# Altium schematic sheet хехема.SchDoc
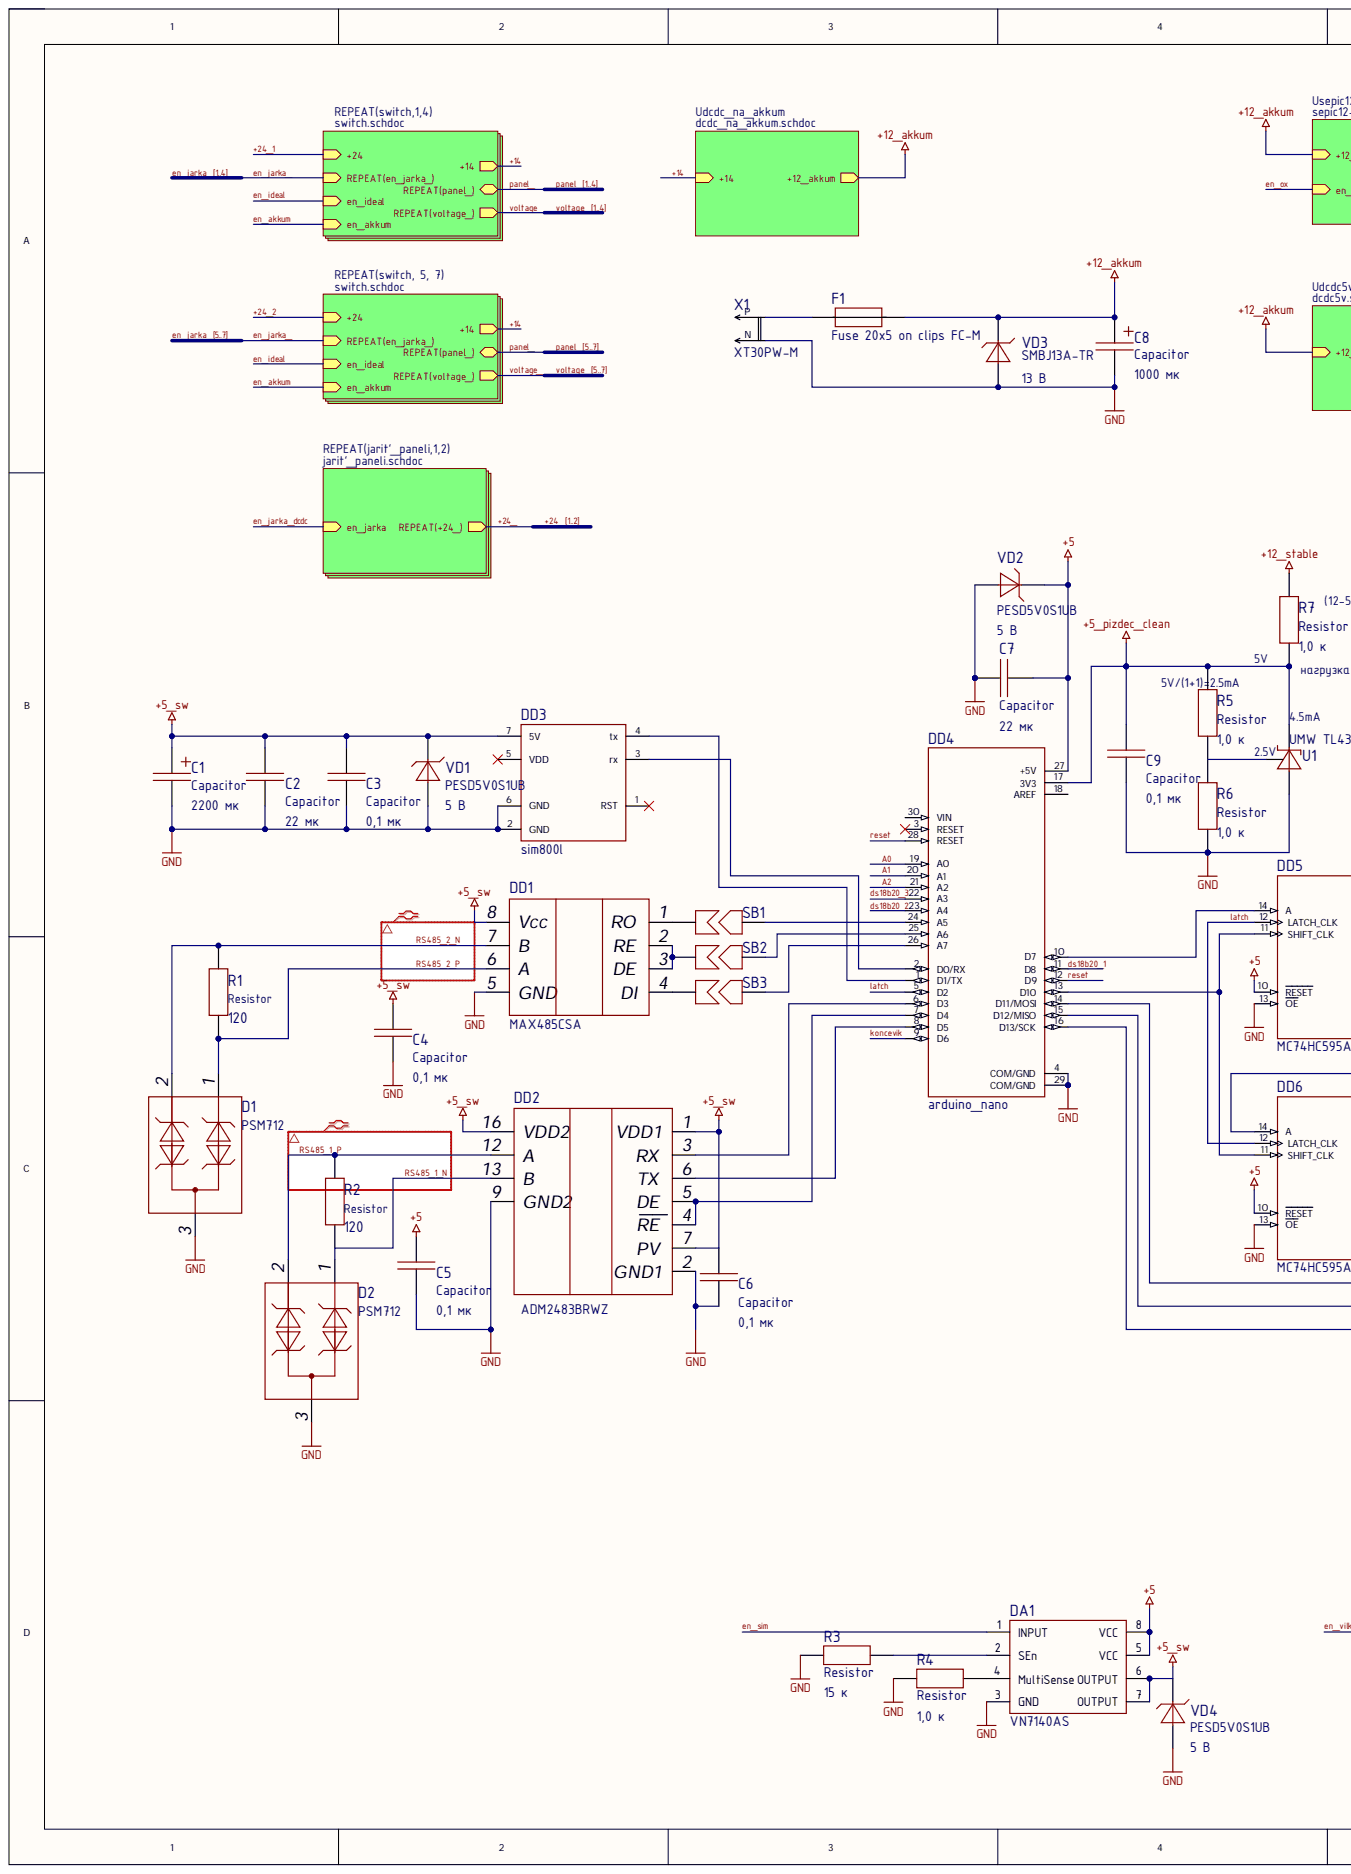
[diagram p0: хехема.SchDoc, left]
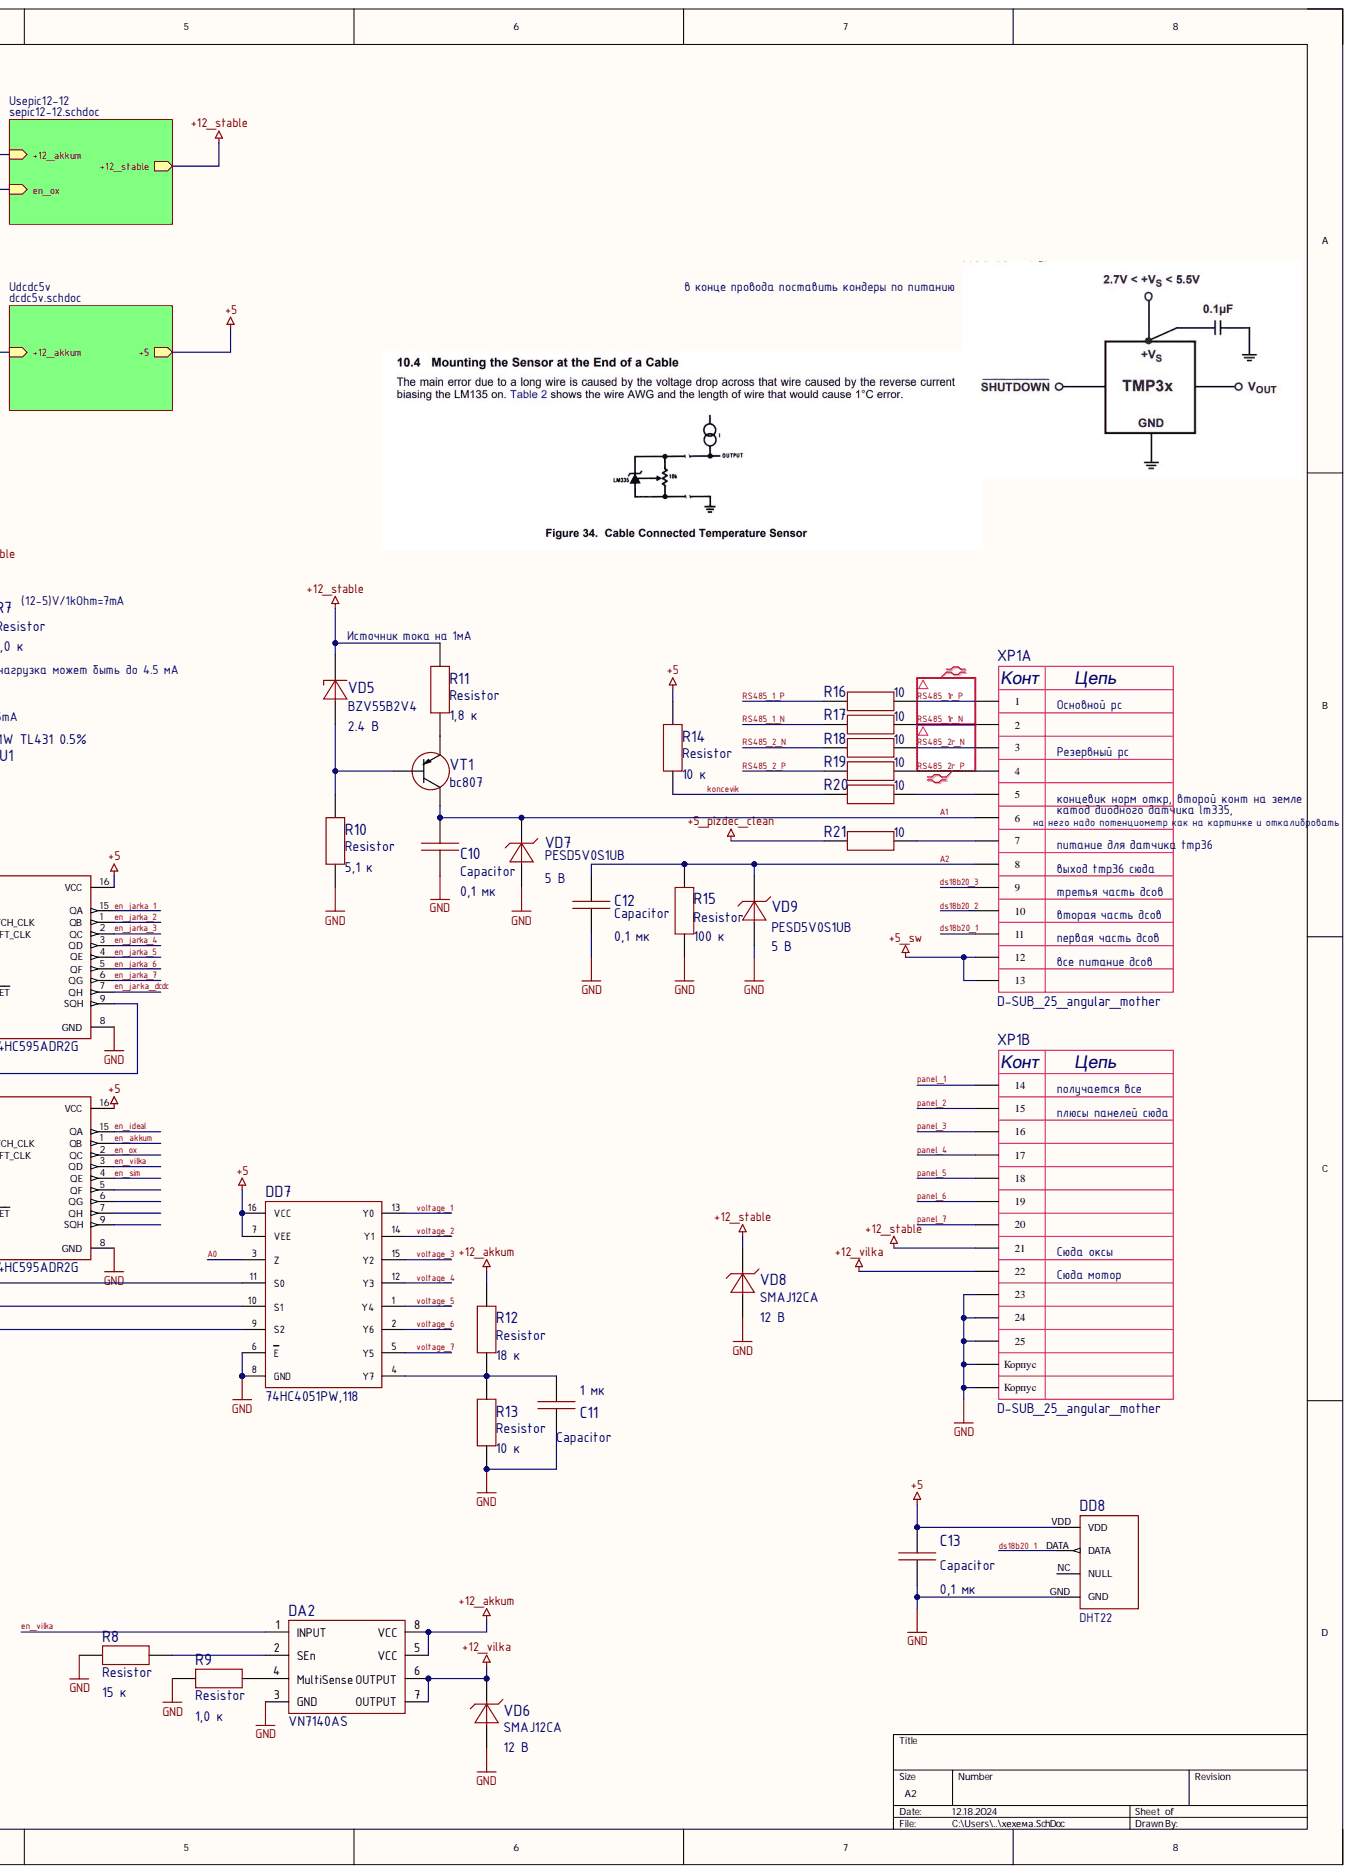
[diagram p1: хехема.SchDoc, right]

## Parts
C1  Capacitor  value 2200 мк  Capacity (uF): 2200  [10x20_radial]
C2  Capacitor  value 22 мк  [1210C]
C3  Capacitor  value 0,1 мк  Tolerance: 0,1  [0603C]
C4  Capacitor  value 0,1 мк  Tolerance: 0,1  [0603C]
C5  Capacitor  value 0,1 мк  Tolerance: 0,1  [0603C]
C6  Capacitor  value 0,1 мк  Tolerance: 0,1  [0603C]
C7  Capacitor  value 22 мк  [1210C]
C8  Capacitor  value 1000 мк  Capacity (uF): 1000  [SMD_cap_12,5x13,5]
C9  Capacitor  value 0,1 мк  Tolerance: 0,1  [0603C]
C10  Capacitor  value 0,1 мк  Tolerance: 0,1  [0603C]
C11  Capacitor  value 1 мк  [0603C]
C12  Capacitor  value 0,1 мк  Tolerance: 0,1  [0603C]
C13  Capacitor  value 0,1 мк  Tolerance: 0,1  [0603C]
D1  PSM712  [SOT23-3]
D2  PSM712  [SOT23-3]
DA1  VN7140AS  [SOP8]
DA2  VN7140AS  [SOP8]
DD1  MAX485CSA  [SOIC-8]
DD2  ADM2483BRWZ  [SOIC-16W]
DD3  sim800l  [sim800l]
DD4  arduino_nano  [arduino_nano]
DD5  MC74HC595ADR2G  [SOIC-16]
DD6  MC74HC595ADR2G  [SOIC-16]
DD7  74HC4051PW,118  [TSSOP-16]
DD8  DHT22  [DHT22]
F1  Fuse 20x5 on clips FC-M  [fuse_20x5_on_clips_FC-M]
R1  Resistor  value 120  [1206R]
R2  Resistor  value 120  [1206R]
R3  Resistor  value 15 к  [0603R]
R4  Resistor  value 1,0 к  [0603R]
R5  Resistor  value 1,0 к  [0603R]
R6  Resistor  value 1,0 к  [0603R]
R7  Resistor  value 1,0 к  [0603R]
R8  Resistor  value 15 к  [0603R]
R9  Resistor  value 1,0 к  [0603R]
R10  Resistor  value 5,1 к  [0603R]
R11  Resistor  value 1,8 к  [0603R]
R12  Resistor  value 18 к  [0603R]
R13  Resistor  value 10 к  [0603R]
R14  Resistor  value 10 к  [0603R]
R15  Resistor  value 100 к  [0603R]
R16  Resistor  value 10  [0603R]
R17  Resistor  value 10  [0603R]
R18  Resistor  value 10  [0603R]
R19  Resistor  value 10  [0603R]
R20  Resistor  value 10  [0603R]
R21  Resistor  value 10  [0603R]
SB1  SB  [0805SB]
SB2  SB  [0805SB]
SB3  SB  [0805SB]
U1  UMW TL431 0.5%  [SOT23-3]
VD1  PESD5V0S1UB  value 5 В  [SOD523]
VD2  PESD5V0S1UB  value 5 В  [SOD523]
VD3  SMBJ13A-TR  value 13 В  [SMB]
VD4  PESD5V0S1UB  value 5 В  [SOD523]
VD5  BZV55B2V4  value 2.4 В  Voltage nominal (V): 2.4  [mini_MELF_3.5mm]
VD6  SMAJ12CA  value 12 В  [SMA]
VD7  PESD5V0S1UB  value 5 В  [SOD523]
VD8  SMAJ12CA  value 12 В  [SMA]
VD9  PESD5V0S1UB  value 5 В  [SOD523]
VT1  bc807  [SOT23-3]
X1  XT30PW-M  [XT30PW-M]
XP1  D-SUB_25_angular_mother  [D-SUB_25_angular_mother]  (parts A,B)

## Nets
+5: C5.2 C7.1 C13.2 DA1.5(VCC) DA1.8(VCC) DD4.27(+5V) DD5.10(RESET) DD5.16(VCC) DD6.10(RESET) DD6.16(VCC) DD7.7(VEE) DD7.16(VCC) DD8.VDD R14.2 VD2.2
+5_pizdec_clean: C9.2 DD4.17(3V3) R5.2 R7.1 R21.1 U1.2
+5_sw: C1.1 C2.2 C3.2 C4.1 C6.2 DA1.6(OUTPUT) DA1.7(OUTPUT) DD1.8(Vcc) DD2.1(VDD1) DD2.7(PV) DD2.16(VDD2) DD3.7(5V) VD1.2 VD4.2 XP1.12 XP1.13
+12_akkum: C8.1 DA2.5(VCC) DA2.8(VCC) F1.2 R12.2 VD3.2
+12_stable: R7.2 R11.2 VD5.2 VD8.2 XP1.21
+12_vilka: DA2.6(OUTPUT) DA2.7(OUTPUT) VD6.2 XP1.22
GND: C1.2 C2.1 C3.1 C4.2 C5.1 C6.1 C7.2 C8.2 C9.1 C10.1 C11.1 C12.1 C13.1 D1.3 D2.3 DA1.3(GND) DA2.3(GND) DD1.5(GND) DD2.2(GND1) DD2.9(GND2) DD3.2(GND) DD3.6(GND) DD4.4(COM/GND) DD4.29(COM/GND) DD5.8(GND) DD5.13(OE) DD6.8(GND) DD6.13(OE) DD7.6(E) DD7.8(GND) DD8.GND R3.1 R4.1 R6.1 R8.1 R9.1 R10.1 R13.1 R15.1 U1.3 VD1.1 VD2.1 VD3.1 VD4.1 VD6.1 VD7.1 VD8.1 VD9.1 X1.N XP1.23 XP1.24 XP1.25 XP1.SH1(Корпус) XP1.SH2(Корпус)
A0: DD4.19(A0) DD7.3(Z)
A1: C10.2 DD4.20(A1) VD7.2 VT1.3 XP1.6
A2: C12.2 DD4.21(A2) R15.2 VD9.2 XP1.8
ds18b20_1: DD4.11(D8) DD8.DATA XP1.11
ds18b20_2: DD4.23(A4) XP1.10
ds18b20_3: DD4.22(A3) XP1.9
en_akkum: DD6.1(QB)
en_ideal: DD6.15(QA)
en_jarka_1: DD5.15(QA)  [also labelled en_jarka_]
en_jarka_2: DD5.1(QB)  [also labelled en_jarka_]
en_jarka_3: DD5.2(QC)  [also labelled en_jarka_]
en_jarka_4: DD5.3(QD)  [also labelled en_jarka_]
en_jarka_5: DD5.4(QE)  [also labelled en_jarka_]
en_jarka_6: DD5.5(QF)  [also labelled en_jarka_]
en_jarka_7: DD5.6(QG)  [also labelled en_jarka_]
en_jarka_dcdc: DD5.7(QH)
en_ox: DD6.2(QC)
en_sim: DA1.1(INPUT) DD6.4(QE)
en_vilka: DA2.1(INPUT) DD6.3(QD)
koncevik: DD4.9(D6) R14.1 R20.1
latch: DD4.5(D2) DD5.12(LATCH_CLK) DD6.12(LATCH_CLK)
panel_1: XP1.14  [also labelled panel_]
panel_2: XP1.15  [also labelled panel_]
panel_3: XP1.16  [also labelled panel_]
panel_4: XP1.17  [also labelled panel_]
panel_5: XP1.18  [also labelled panel_]
panel_6: XP1.19  [also labelled panel_]
panel_7: XP1.20  [also labelled panel_]
reset: DD4.12(D9) DD4.28(RESET)
RS485_1_N: D2.1 DD2.13(B) R2.1 R17.1
RS485_1_P: D2.2 DD2.12(A) R2.2 R16.1
RS485_1r_N: R17.2 XP1.2
RS485_1r_P: R16.2 XP1.1
RS485_2_N: D1.2 DD1.7(B) R1.2 R18.1
RS485_2_P: D1.1 DD1.6(A) R1.1 R19.1
RS485_2r_N: R18.2 XP1.3
RS485_2r_P: R19.2 XP1.4
voltage_1: DD7.13(Y0)  [also labelled voltage_]
voltage_2: DD7.14(Y1)  [also labelled voltage_]
voltage_3: DD7.15(Y2)  [also labelled voltage_]
voltage_4: DD7.12(Y3)  [also labelled voltage_]
voltage_5: DD7.1(Y4)  [also labelled voltage_]
voltage_6: DD7.2(Y6)  [also labelled voltage_]
voltage_7: DD7.5(Y5)  [also labelled voltage_]
NetC11_2: C11.2 DD7.4(Y7) R12.1 R13.2
NetDA1_2: DA1.2(SEn) R3.2
NetDA1_4: DA1.4(MultiSense) R4.2
NetDA2_2: DA2.2(SEn) R8.2
NetDA2_4: DA2.4(MultiSense) R9.2
NetDD1_1: DD1.1(RO) SB1.1
NetDD1_2: DD1.2(RE) DD1.3(DE) SB2.1
NetDD1_4: DD1.4(DI) SB3.1
NetDD2_3: DD2.3(RX) DD4.6(D3)
NetDD2_4: DD2.4(RE) DD2.5(DE) DD4.7(D4)
NetDD2_6: DD2.6(TX) DD4.8(D5)
NetDD3_1: DD3.1(RST)
NetDD3_3: DD3.3(rx) DD4.2(D0/RX)
NetDD3_4: DD3.4(tx) DD4.1(D1/TX)
NetDD3_5: DD3.5(VDD)
NetDD4_3: DD4.3(RESET)
NetDD4_10: DD4.10(D7) DD5.14(A)
NetDD4_13: DD4.13(D10) DD5.11(SHIFT_CLK) DD6.11(SHIFT_CLK)
NetDD4_14: DD4.14(D11/MOSI) DD7.11(S0)
NetDD4_15: DD4.15(D12/MISO) DD7.10(S1)
NetDD4_16: DD4.16(D13/SCK) DD7.9(S2)
NetDD4_18: DD4.18(AREF)
NetDD4_24: DD4.24(A5) SB1.2
NetDD4_25: DD4.25(A6) SB2.2
NetDD4_26: DD4.26(A7) SB3.2
NetDD4_30: DD4.30(VIN)
NetDD5_9: DD5.9(SQH) DD6.14(A)
NetDD6_5: DD6.5(QF)
NetDD6_6: DD6.6(QG)
NetDD6_7: DD6.7(QH)
NetDD6_9: DD6.9(SQH)
NetDD8_NC: DD8.NC(NULL)
NetF1_1: F1.1 X1.P
NetR5_1: R5.1 R6.2 U1.1
NetR10_2: R10.2 VD5.1 VT1.1
NetR11_1: R11.1 VT1.2
NetR20_2: R20.2 XP1.5
NetR21_2: R21.2 XP1.7
+14:
+24_1:  [also labelled +24_]
+24_2:  [also labelled +24_]
en_jarka_[1..4]:  [also labelled en_jarka_]
en_jarka_[5..7]:  [also labelled en_jarka_]
panel_[1..4]:  [also labelled panel_]
panel_[5..7]:  [also labelled panel_]
voltage_[1..4]:  [also labelled voltage_]
voltage_[5..7]:  [also labelled voltage_]

## Library data of the parts
C1 = Capacitor_2200u_10V_10x20: Конденсатор радиальный 2200мкФ 10В ±20% 10*19; Database Table Name: Capacitors_electrolytic$; Tolerance: 20; Type: Aluminum; Value: 2200 мк; Voltage (V): 10; Component Kind: Standard; Library Name: Hydrolib.DbLib; Part Number: Capacitor_2200u_10V_10x20
C2 = Capacitor_22u_10_25V_X7R_1210C: Конденсатор; Capacity (nF): 22000; Database Table Name: Capacitors_ceramic$; Dielectric: X7R; Tolerance: 0,1; Voltage (V): 25; Component Kind: Standard; Library Name: Hydrolib.DbLib; Part Number: Capacitor_22u_10_25V_X7R_1210C
C3 C4 C6 C12 C13 = Capacitor_0,1u_10_50V_X7R_0603C: Конденсатор; Capacity (nF): 100; Database Table Name: Capacitors_ceramic$; Dielectric: X7R; Voltage (V): 50; Component Kind: Standard; Library Name: Hydrolib.DbLib; Part Number: Capacitor_0,1u_10_50V_X7R_0603C
C5 C9 C10 = Capacitor_0,1u_10_50V_X7R_0603C: Конденсатор; Capacity (nF): 100; Database Table Name: Capacitors_ceramic$; Dielectric: X7R; Value: 0,1 мк; Voltage (V): 50; Component Kind: Standard; Library Name: Hydrolib.DbLib; Part Number: Capacitor_0,1u_10_50V_X7R_0603C
C7 = Capacitor_22u_10_25V_X7R_1210C: Конденсатор; Capacity (nF): 22000; Database Table Name: Capacitors_ceramic$; Dielectric: X7R; Tolerance: 0,1; Value: 22 мк; Voltage (V): 25; Component Kind: Standard; Library Name: Hydrolib.DbLib; Part Number: Capacitor_22u_10_25V_X7R_1210C
C8 = EEVFK1E102Q: Конденсатор алюминиевый электролитический 1000мкФ 25В 20% Low ESR 1100мА SMD12.5x13.5мм; Database Table Name: Capacitors_electrolytic$; Tolerance: 20; Type: Aluminum; Voltage (V): 25; Component Kind: Standard; Library Name: Hydrolib.DbLib; Part Number: EEVFK1E102Q
C11 = Capacitor_1u_10_50V_X7R_0603C: Конденсатор; Capacity (nF): 1000; Database Table Name: Capacitors_ceramic$; Dielectric: X7R; Tolerance: 0,1; Voltage (V): 50; Component Kind: Standard; Library Name: Hydrolib.DbLib; Part Number: Capacitor_1u_10_50V_X7R_0603C
D1 D2 = PSM712: Защитный диод для линии RS-485; Database Table Name: TVS$; Component Kind: Standard; Library Name: Hydrolib.DbLib; Part Number: PSM712
DA1 DA2 = VN7140AS: Силовой ключ верхнего плеча с аналоговой обратной связью MultiSense автомобильного применения; Database Table Name: Chips$; Component Kind: Standard; Library Name: Hydrolib.DbLib; Part Number: VN7140AS
DD1 = MAX485CSA: RS-485 приёмопередатчик; Database Table Name: Chips$; Component Kind: Standard; Library Name: Hydrolib.DbLib; Part Number: MAX485CSA
DD2 = ADM2483BRWZ: Прием передатчик RS485 с гальванической развязкой; Database Table Name: Chips$; Component Kind: Standard; Library Name: Hydrolib.DbLib; Part Number: ADM2483BRWZ
DD3 = sim800l: Четырехдиапазонный GSM/GPRS модуль, частоты: GSM 850 МГц, EGSM 900 МГц, DCS 1800 МГц и PCS 1900 МГц.; Database Table Name: Chips$; Component Kind: Standard; Library Name: Hydrolib.DbLib; Part Number: sim800l
DD4 = arduino_nano: ардуинка на стойках; Database Table Name: Chips$; MP: Arduino Nano; Availability: Not in stock; Check_prices: https://www.snapeda.com/parts/Arduino%20Nano/Arduino/view-part/?ref=eda; Component Kind: Standard; Library Name: Hydrolib.DbLib; MF: Arduino; Package: Non-Standard Arduino; Part Number: arduino_nano; Price: None; SnapEDA_Link: https://www.snapeda.com/parts/Arduino%20Nano/Arduino/view-part/?ref=snap
DD5 DD6 = MC74HC595ADR2G: 8-ми битный сдвиговый регистр; Database Table Name: Chips$; Component Kind: Standard; Library Name: Hydrolib.DbLib; Part Number: MC74HC595ADR2G
DD7 = 74HC4051PW,118: Мультиплексор аналоговый 8 в 1 КМОП кристалл, TSSOP16; Database Table Name: Chips$; Component Kind: Standard; Library Name: Hydrolib.DbLib; Part Number: 74HC4051PW,118
DD8 = DHT22: Датчик температуры и влажности на базе AM2302; Database Table Name: Other$; Component Kind: Standard; Library Name: Hydrolib.DbLib; Part Number: DHT22
F1 = Fuse 20x5 on clips FC-M: Предохранитель 20*5 на креплениях; Database Table Name: Other$; Value: {Номинал}; Component Kind: Standard; Library Name: Hydrolib.DbLib; Part Number: Fuse 20x5 on clips FC-M
R1 R2 = Resistor_120_1_0603: Резистор номиналом 120 Ом и точностью 1%; Database Table Name: Resistors$; Component Kind: Standard; Library Name: Hydrolib.DbLib; Part Number: Resistor_120_1_0603; Tolerance: 1%
R3 R8 = Resistor_15k_1_0603: Резистор номиналом 15 кОм и точностью 1%; Database Table Name: Resistors$; Component Kind: Standard; Library Name: Hydrolib.DbLib; Part Number: Resistor_15k_1_0603; Tolerance: 1%
R4 R6 R7 R9 = Resistor_1.0k_1_0603: Резистор номиналом 1,0 кОм и точностью 1%; Database Table Name: Resistors$; Component Kind: Standard; Library Name: Hydrolib.DbLib; Part Number: Resistor_1.0k_1_0603; Tolerance: 1%
R5 = Resistor_1.0k_1_0603: Резистор номиналом 1,0 кОм и точностью 1%; Database Table Name: Resistors$; Value: 1,0 к; Component Kind: Standard; Library Name: Hydrolib.DbLib; Part Number: Resistor_1.0k_1_0603; Tolerance: 1%
R10 = Resistor_5.1k_1_0603: Резистор номиналом 5,1 кОм и точностью 1%; Database Table Name: Resistors$; Component Kind: Standard; Library Name: Hydrolib.DbLib; Part Number: Resistor_5.1k_1_0603; Tolerance: 1%
R11 = Resistor_1.8k_1_0603: Резистор номиналом 1,8 кОм и точностью 1%; Database Table Name: Resistors$; Component Kind: Standard; Library Name: Hydrolib.DbLib; Part Number: Resistor_1.8k_1_0603; Tolerance: 1%
R12 = Resistor_18k_1_0603: Резистор номиналом 18 кОм и точностью 1%; Database Table Name: Resistors$; Component Kind: Standard; Library Name: Hydrolib.DbLib; Part Number: Resistor_18k_1_0603; Tolerance: 1%
R13 R14 = Resistor_10k_1_0603: Резистор номиналом 10 кОм и точностью 1%; Database Table Name: Resistors$; Component Kind: Standard; Library Name: Hydrolib.DbLib; Part Number: Resistor_10k_1_0603; Tolerance: 1%
R15 = Resistor_100k_1_0603: Резистор номиналом 100 кОм и точностью 1%; Database Table Name: Resistors$; Value: 100 к; Component Kind: Standard; Library Name: Hydrolib.DbLib; Part Number: Resistor_100k_1_0603; Tolerance: 1%
R16-R21 = Resistor_10_1_0603: Резистор номиналом 10 Ом и точностью 1%; Database Table Name: Resistors$; Component Kind: Standard; Library Name: Hydrolib.DbLib; Part Number: Resistor_10_1_0603; Tolerance: 1%
SB1-SB3 = SB: Solder bridge, мост припоя, запаиваемая перемычка, net tie, jumper; Database Table Name: Other$; Component Kind: Standard; Library Name: Hydrolib.DbLib; Part Number: SB
U1 = UMW TL431 0.5%: Источник опорного напряжения , программируемый стабилитрон [SOT-23-3]; Database Table Name: Other$; Component Kind: Standard; Library Name: Hydrolib.DbLib; Part Number: UMW TL431 0.5%
VD1 VD4 VD9 = PESD5V0S1UB: Защитный диод на 5 В, рассеиваемая мощность 330 Вт; Database Table Name: TVS$; Power (W): 330; Voltage nominal (V): 5; Component Kind: Standard; Library Name: Hydrolib.DbLib; Part Number: PESD5V0S1UB
VD2 VD7 = PESD5V0S1UB: Защитный диод на 5 В, рассеиваемая мощность 330 Вт; Database Table Name: TVS$; Power (W): 330; Value: 5 В; Voltage nominal (V): 5; Component Kind: Standard; Library Name: Hydrolib.DbLib; Part Number: PESD5V0S1UB
VD3 = SMBJ13A-TR: Защитный диод на 13 В, рассеиваемая мощность 600 Вт; Database Table Name: TVS$; Power (W): 600; Voltage nominal (V): 13; Component Kind: Standard; Library Name: Hydrolib.DbLib; Part Number: SMBJ13A-TR
VD5 = BZV55B2V4: Стабилитрон на 2.4 В, рассеиваемая мощность 0.5 Вт; Current nominal (mA): 5; Database Table Name: Zener$; Power (W): 0.3; Value: 2.4 В; Component Kind: Standard; Library Name: Hydrolib.DbLib; Part Number: BZV55B2V4
VD6 VD8 = SMAJ12CA: Защитный диод на 12 В, рассеиваемая мощность 400 Вт; Database Table Name: TVS$; Power (W): 400; Voltage nominal (V): 12; Component Kind: Standard; Library Name: Hydrolib.DbLib; Part Number: SMAJ12CA
VT1 = bc807: Биполярный транзистор PNP 45В 0.5А 0.31Вт; Database Table Name: Transistors$; Component Kind: Standard; Library Name: Hydrolib.DbLib; Part Number: bc807; Напряжение С-И/К-Э: 45 В; Ток макс#: 0.5 А
X1 = XT30PW-M: 30 А (15 продолжительно), 2 контакта разъем угловой отец; Database Table Name: Connectors$; Component Kind: Standard; Library Name: Hydrolib.DbLib; Part Number: XT30PW-M
XP1 = D-SUB_25_angular_mother: Разъем D-Sub 25 конт. "гнездо" на плату L = 8.1 мм (7.2), прямой угол, никелир. фланец, латун. винты и фиксат. (аналог DRB-25FA/ DBR-25F) Соединитель, тип конструкции DRB, число и тип контактов (F-гнездо,M-штырь,R-угловой): 25F; Database Table Name: Connectors$; Component Kind: Standard; Library Name: Hydrolib.DbLib; Part Number: D-SUB_25_angular_mother
